# Altium schematic sheet LSHM_Conns.SchDoc
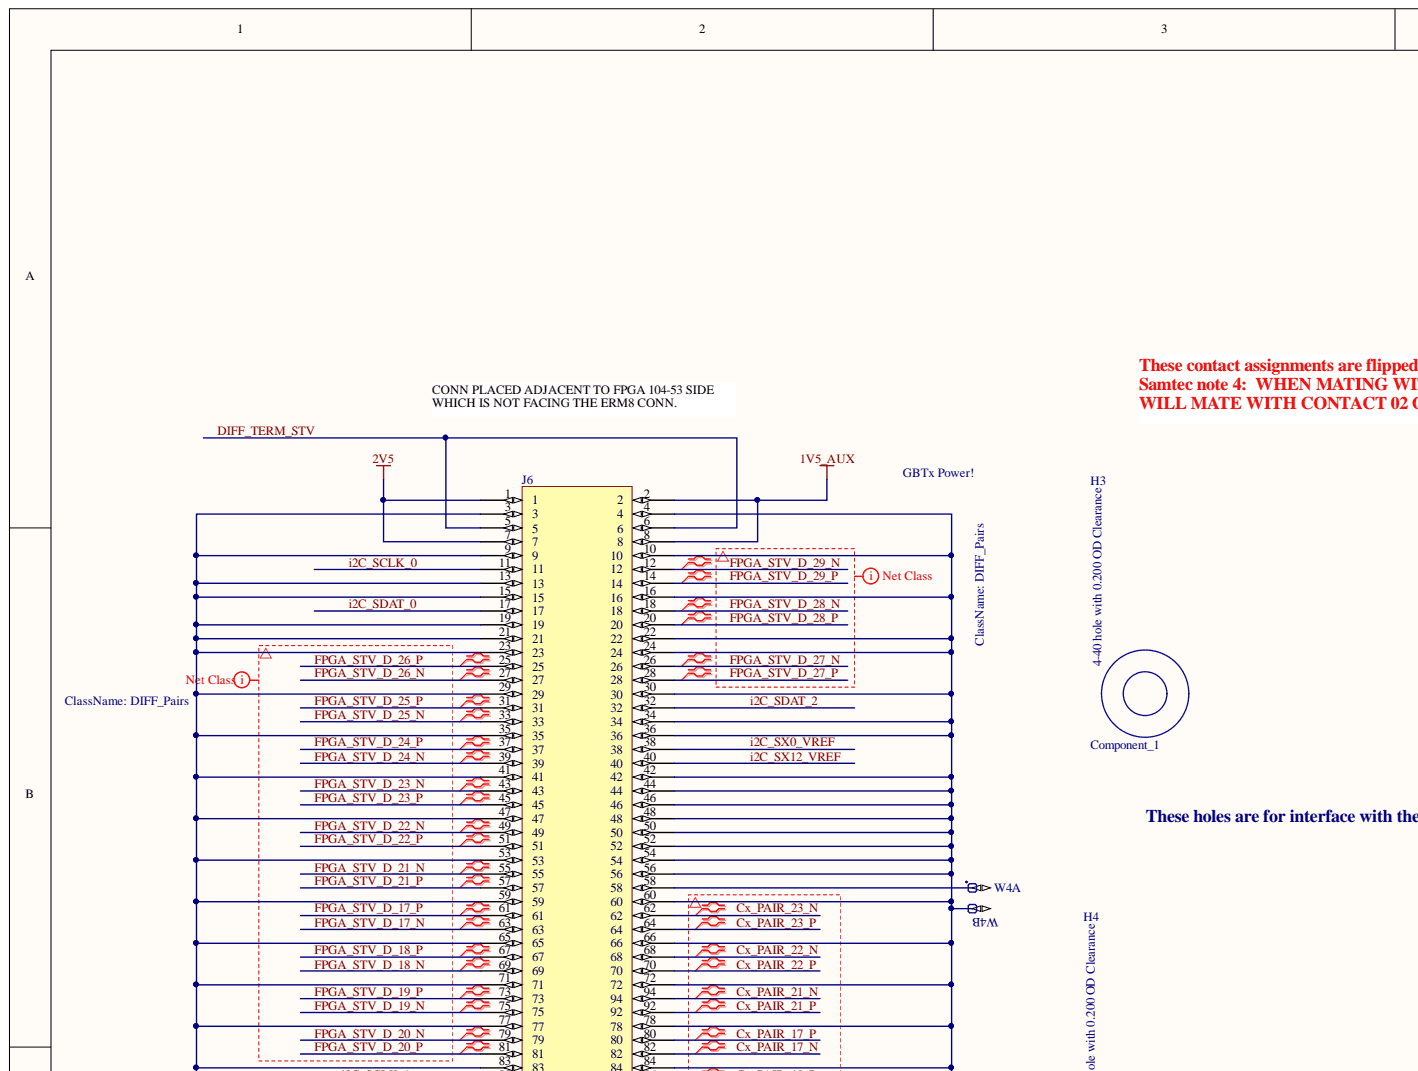
[diagram p0: LSHM_Conns.SchDoc, top left]
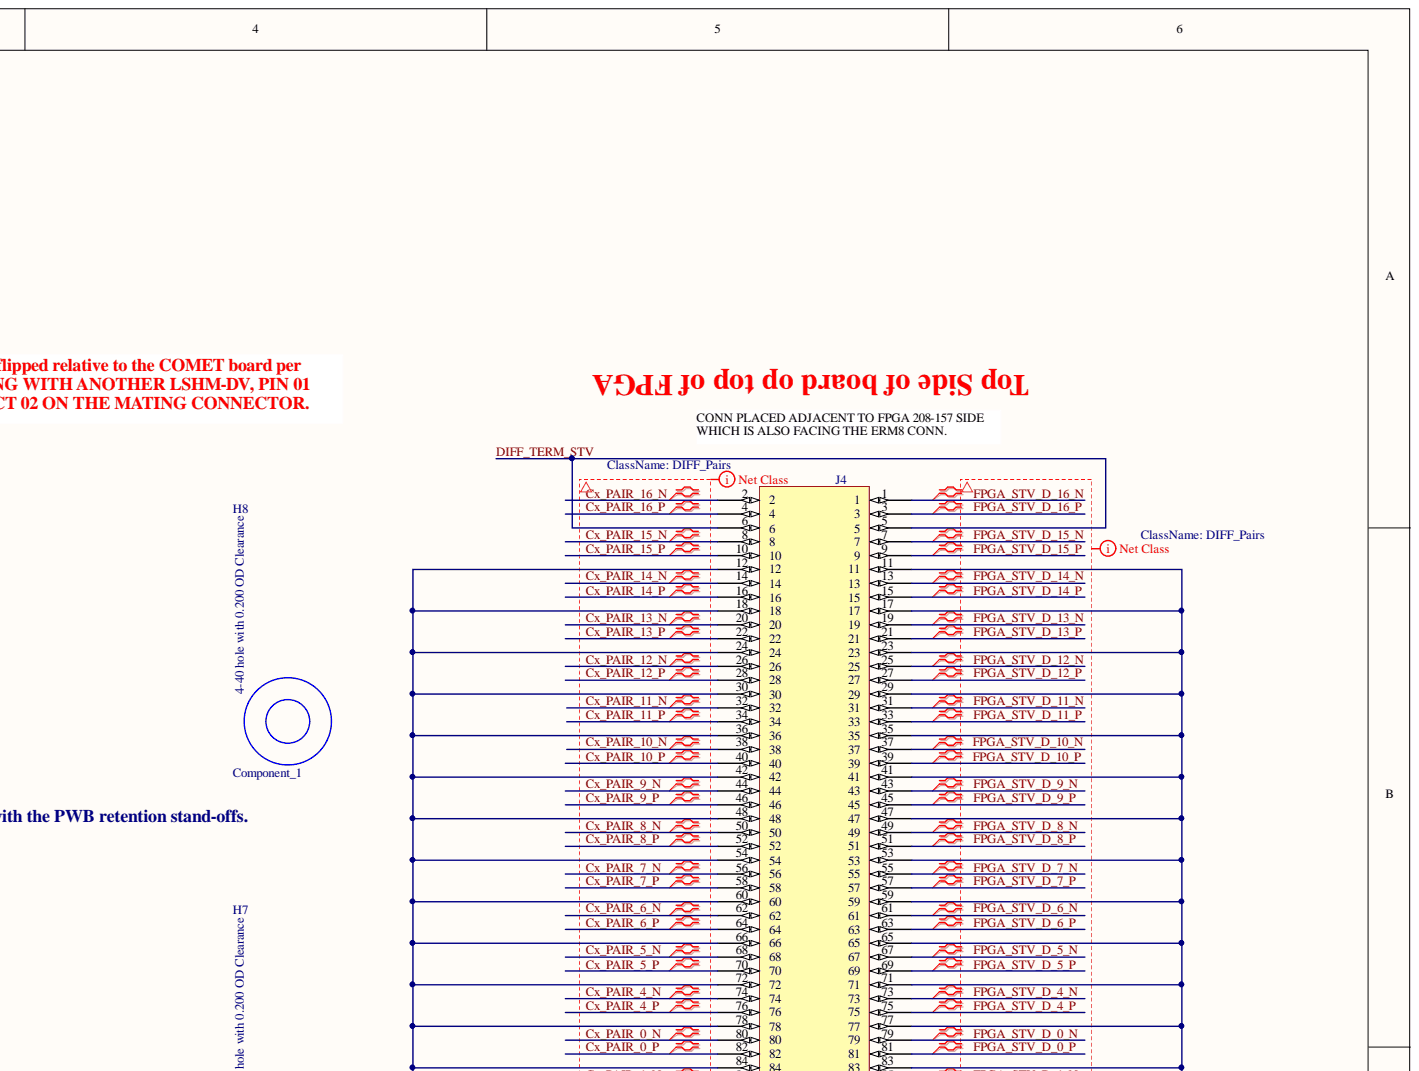
[diagram p1: LSHM_Conns.SchDoc, top right]
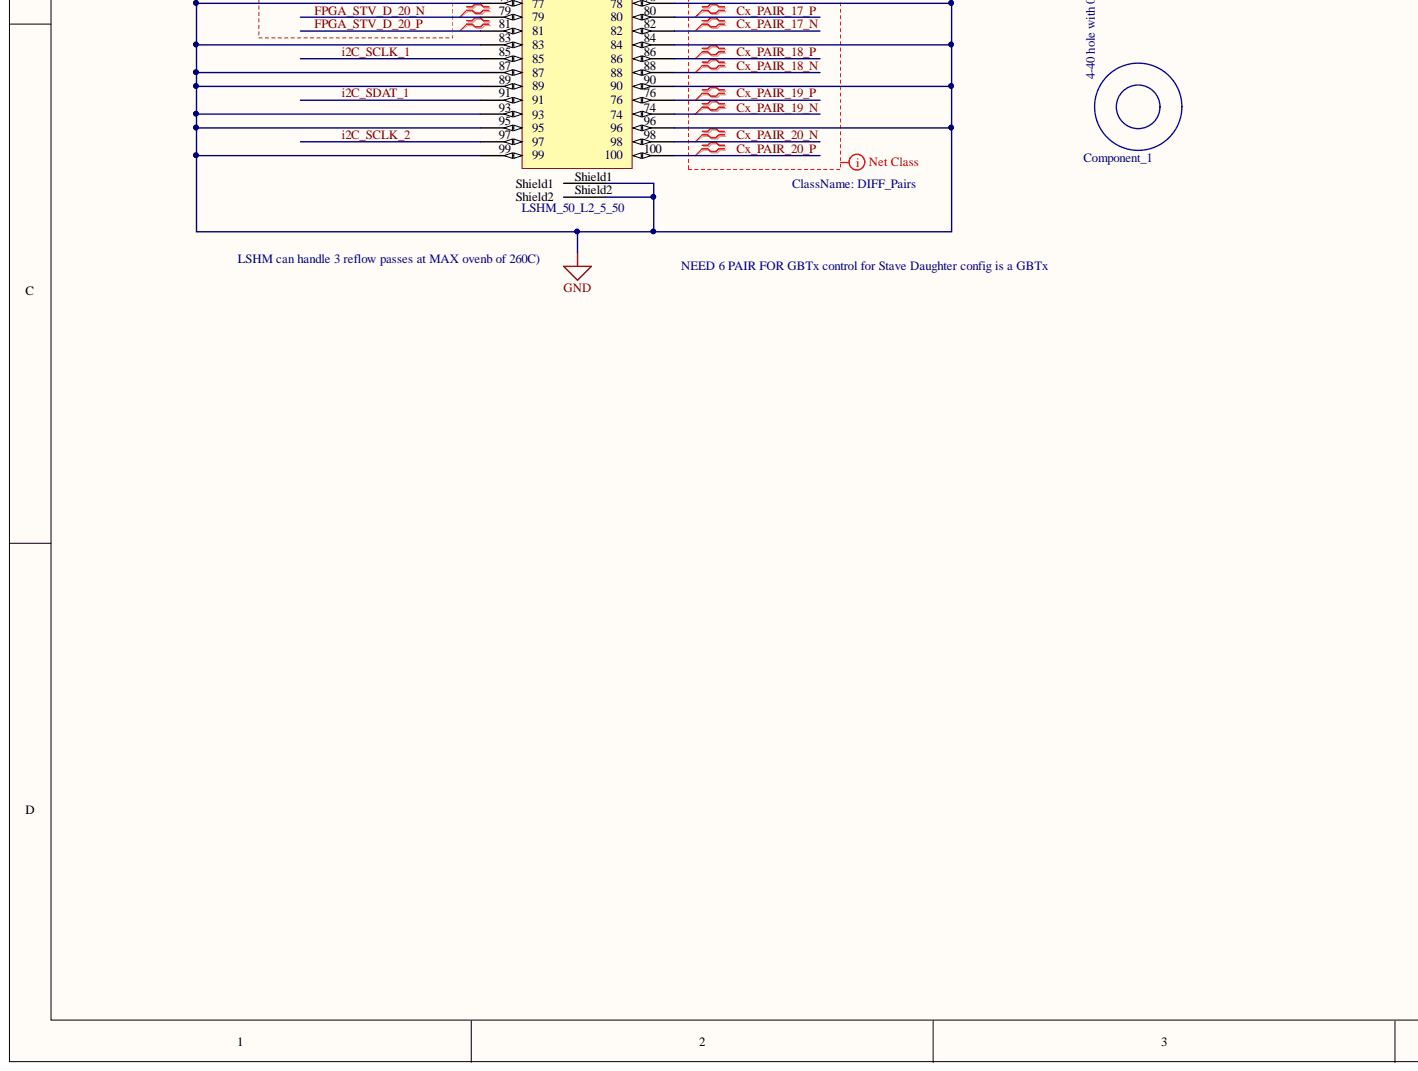
[diagram p2: LSHM_Conns.SchDoc, bottom left]
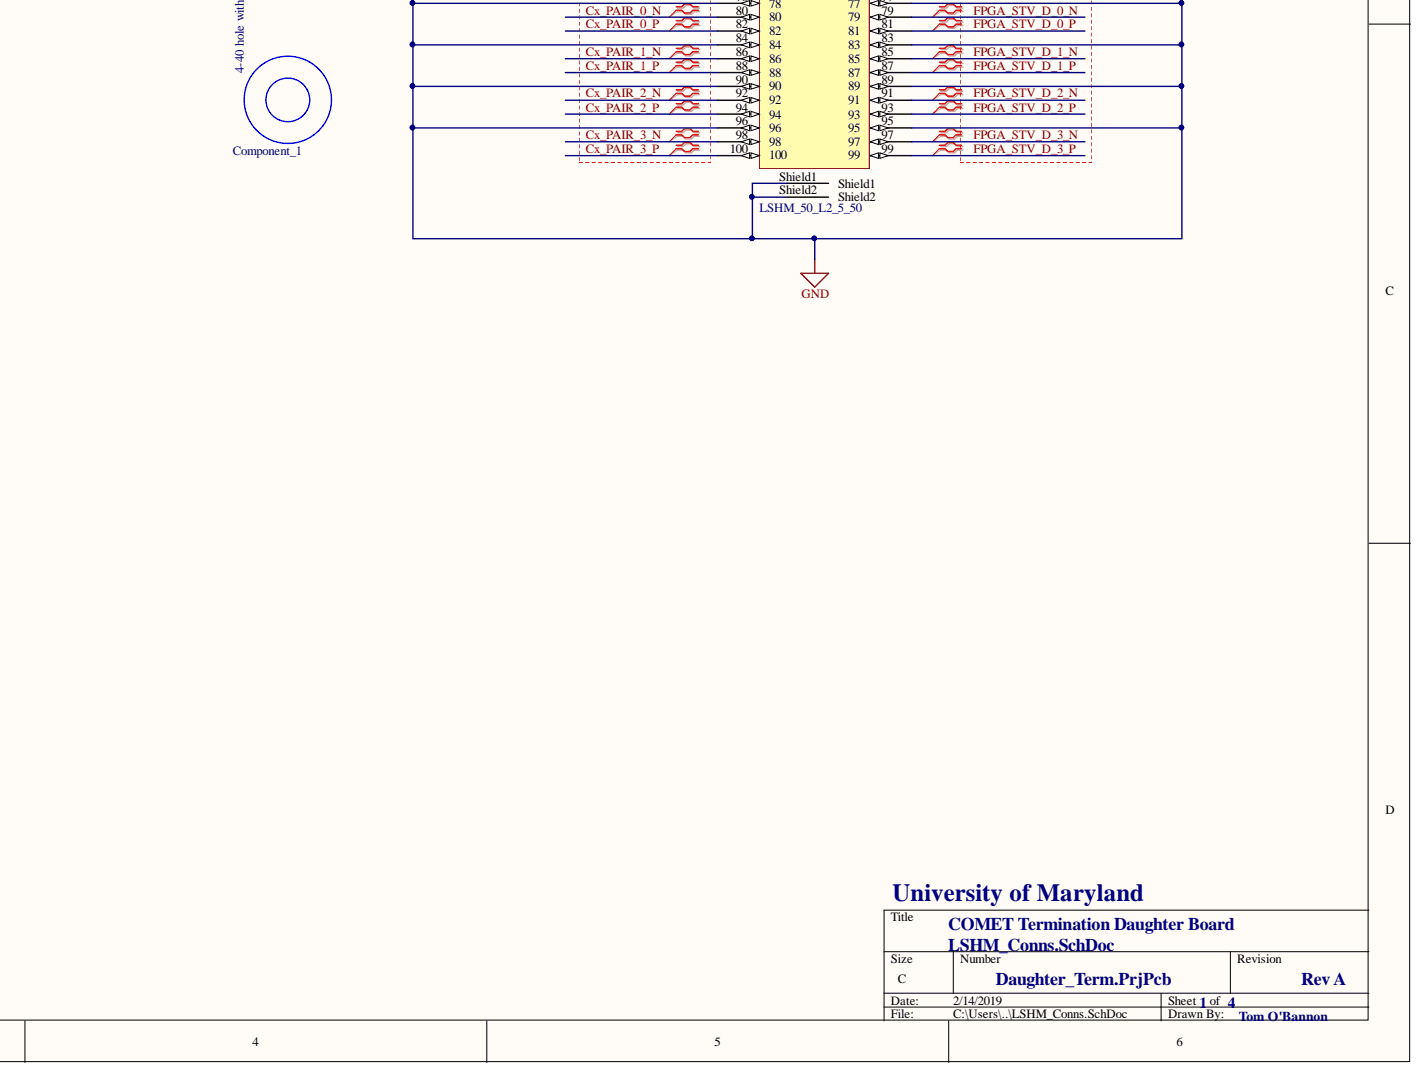
[diagram p3: LSHM_Conns.SchDoc, bottom right]

## Parts
H3  Component_1  [200clear_440Hold]
H4  Component_1  [200clear_440Hold]
H7  Component_1  [200clear_440Hold]
H8  Component_1  [200clear_440Hold]
J4  LSHM_50_L2_5_50  [LSHM_150]
J6  LSHM_50_L2_5_50  [LSHM_150]
W4  Header 2  [SAMTEC_TSW-102-23-L-S]  (value not drawn)  (parts A,B)

## Nets
1V5_AUX: J6.2 J6.8
2V5: J6.1 J6.7
GND: J4.11 J4.12 J4.17 J4.18 J4.23 J4.24 J4.29 J4.30 J4.35 J4.36 J4.41 J4.42 J4.47 J4.48 J4.53 J4.54 J4.59 J4.60 J4.65 J4.66 J4.71 J4.72 J4.77 J4.78 J4.83 J4.84 J4.89 J4.90 J4.95 J4.96 J4.Shield1 J4.Shield2 J6.3 J6.4 J6.9 J6.10 J6.13 J6.15 J6.16 J6.19 J6.21 J6.22 J6.23 J6.24 J6.29 J6.30 J6.34 J6.35 J6.36 J6.41 J6.42 J6.44 J6.46 J6.47 J6.48 J6.50 J6.52 J6.53 J6.54 J6.56 J6.59 J6.60 J6.65 J6.66 J6.71 J6.72 J6.77 J6.78 J6.83 J6.84 J6.87 J6.89 J6.90 J6.93 J6.95 J6.96 J6.99 J6.Shield1 J6.Shield2 W4.2
CX_PAIR_0_N: J4.80
CX_PAIR_0_P: J4.82
CX_PAIR_1_N: J4.86
CX_PAIR_1_P: J4.88
CX_PAIR_2_N: J4.92
CX_PAIR_2_P: J4.94
CX_PAIR_3_N: J4.98
CX_PAIR_3_P: J4.100
CX_PAIR_4_N: J4.74
CX_PAIR_4_P: J4.76
CX_PAIR_5_N: J4.68
CX_PAIR_5_P: J4.70
CX_PAIR_6_N: J4.62
CX_PAIR_6_P: J4.64
CX_PAIR_7_N: J4.56
CX_PAIR_7_P: J4.58
CX_PAIR_8_N: J4.50
CX_PAIR_8_P: J4.52
CX_PAIR_9_N: J4.44
CX_PAIR_9_P: J4.46
CX_PAIR_10_N: J4.38
CX_PAIR_10_P: J4.40
CX_PAIR_11_N: J4.32
CX_PAIR_11_P: J4.34
CX_PAIR_12_N: J4.26
CX_PAIR_12_P: J4.28
CX_PAIR_13_N: J4.20
CX_PAIR_13_P: J4.22
CX_PAIR_14_N: J4.14
CX_PAIR_14_P: J4.16
CX_PAIR_15_N: J4.8
CX_PAIR_15_P: J4.10
CX_PAIR_16_N: J4.2
CX_PAIR_16_P: J4.4
CX_PAIR_17_N: J6.82
CX_PAIR_17_P: J6.80
CX_PAIR_18_N: J6.88
CX_PAIR_18_P: J6.86
CX_PAIR_19_N: J6.74
CX_PAIR_19_P: J6.76
CX_PAIR_20_N: J6.98
CX_PAIR_20_P: J6.100
CX_PAIR_21_N: J6.94
CX_PAIR_21_P: J6.92
CX_PAIR_22_N: J6.68
CX_PAIR_22_P: J6.70
CX_PAIR_23_N: J6.62
CX_PAIR_23_P: J6.64
DIFF_TERM_STV: J4.5 J4.6 J6.5 J6.6
FPGA_STV_D_0_N: J4.79
FPGA_STV_D_0_P: J4.81
FPGA_STV_D_1_N: J4.85
FPGA_STV_D_1_P: J4.87
FPGA_STV_D_2_N: J4.91
FPGA_STV_D_2_P: J4.93
FPGA_STV_D_3_N: J4.97
FPGA_STV_D_3_P: J4.99
FPGA_STV_D_4_N: J4.73
FPGA_STV_D_4_P: J4.75
FPGA_STV_D_5_N: J4.67
FPGA_STV_D_5_P: J4.69
FPGA_STV_D_6_N: J4.61
FPGA_STV_D_6_P: J4.63
FPGA_STV_D_7_N: J4.55
FPGA_STV_D_7_P: J4.57
FPGA_STV_D_8_N: J4.49
FPGA_STV_D_8_P: J4.51
FPGA_STV_D_9_N: J4.43
FPGA_STV_D_9_P: J4.45
FPGA_STV_D_10_N: J4.37
FPGA_STV_D_10_P: J4.39
FPGA_STV_D_11_N: J4.31
FPGA_STV_D_11_P: J4.33
FPGA_STV_D_12_N: J4.25
FPGA_STV_D_12_P: J4.27
FPGA_STV_D_13_N: J4.19
FPGA_STV_D_13_P: J4.21
FPGA_STV_D_14_N: J4.13
FPGA_STV_D_14_P: J4.15
FPGA_STV_D_15_N: J4.7
FPGA_STV_D_15_P: J4.9
FPGA_STV_D_16_N: J4.1
FPGA_STV_D_16_P: J4.3
FPGA_STV_D_17_N: J6.63
FPGA_STV_D_17_P: J6.61
FPGA_STV_D_18_N: J6.69
FPGA_STV_D_18_P: J6.67
FPGA_STV_D_19_N: J6.75
FPGA_STV_D_19_P: J6.73
FPGA_STV_D_20_N: J6.79
FPGA_STV_D_20_P: J6.81
FPGA_STV_D_21_N: J6.55
FPGA_STV_D_21_P: J6.57
FPGA_STV_D_22_N: J6.49
FPGA_STV_D_22_P: J6.51
FPGA_STV_D_23_N: J6.43
FPGA_STV_D_23_P: J6.45
FPGA_STV_D_24_N: J6.39
FPGA_STV_D_24_P: J6.37
FPGA_STV_D_25_N: J6.33
FPGA_STV_D_25_P: J6.31
FPGA_STV_D_26_N: J6.27
FPGA_STV_D_26_P: J6.25
FPGA_STV_D_27_N: J6.26
FPGA_STV_D_27_P: J6.28
FPGA_STV_D_28_N: J6.18
FPGA_STV_D_28_P: J6.20
FPGA_STV_D_29_N: J6.12
FPGA_STV_D_29_P: J6.14
I2C_SCLK_0: J6.11
I2C_SCLK_1: J6.85
I2C_SCLK_2: J6.97
I2C_SDAT_0: J6.17
I2C_SDAT_1: J6.91
I2C_SDAT_2: J6.32
I2C_SX0_VREF: J6.38
I2C_SX12_VREF: J6.40
NetJ6_58: J6.58 W4.1
N00121:
N00122:
N00123:
N00124:
N00125:

## Library data of the parts
W4 = SAMTEC_TSW-102-23-L-S: 2 Mini Wire-Wrap Contact Strip Header; Part Description: 2 Contacts - Pitch 2.54mm - Single Row  Through Mount Straigh Header (Post Lead 5.84mm, Tail Lead 2.92mm, Insulator 2.54mm); Database Table Name: SAMTEC; ComponentHeight: 8.38mm; Component Kind: Standard; Component Type: Standard; CreateDate: 10/10/2012; Family: Header 2; HelpURL: \\cern.ch\dfs\Applications\Altium\Datasheets\SAMTEC_TSW-102-23-L-S.pdf; LatestRevisionDate: 10/10/2012; Library Name: Connectors.DbLib; Manufacturer: SAMTEC; Manufacturer Part Number: TSW-102-23-L-S; Mounted: Yes; Part Number: SAMTEC_TSW-102-23-L-S; Pin Count: 2; SMD: No; Socket: No; Status: None; Table: SAMTEC; Author: CERN DEM JLC
H3 H4 H7 H8 = Component_1: Component Kind: Standard; Library Name: 200od_440hole.SchLib
J4 J6 = LSHM_50_L2_5_50: Component Kind: Standard; Library Name: Intg_Lib_Samtec_Conns.IntLib
